# Altium schematic sheet AGCCAL_Top_Level.SchDoc(AGCCAL_Top_Level)
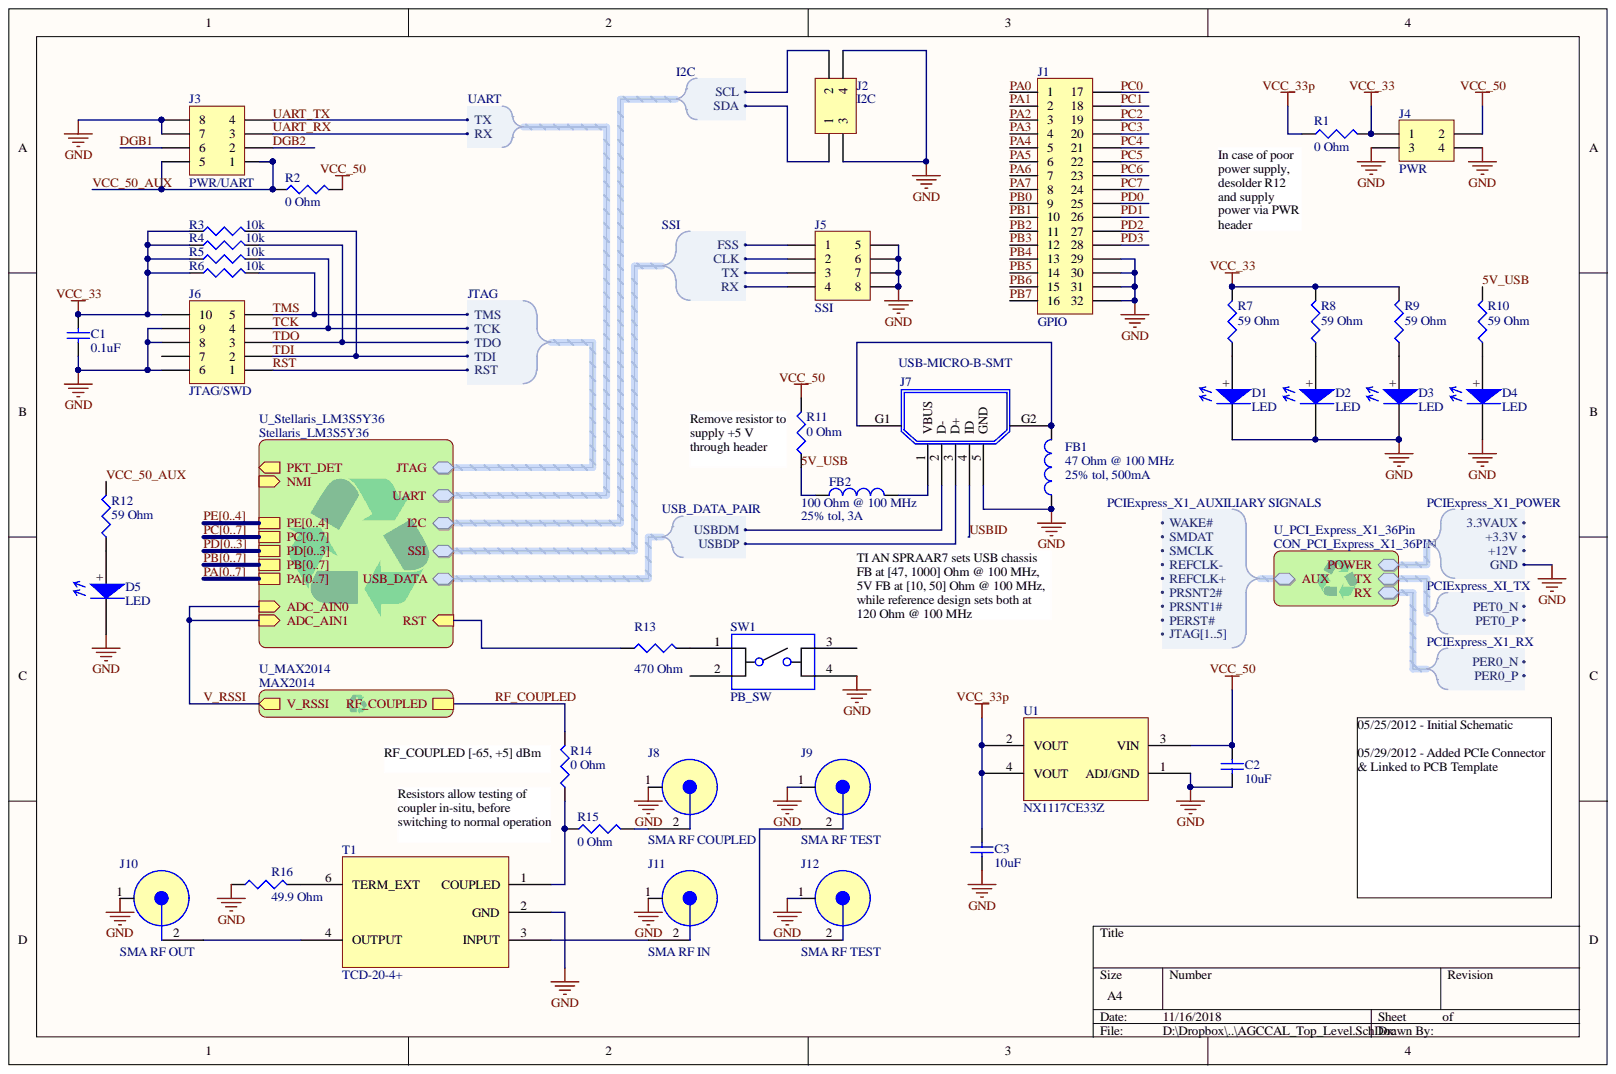
[diagram p0: AGCCAL_Top_Level.SchDoc]

## Parts
C1  value 0.1uF  [1608[0603]]  (hidden comment: Cap Semi)
C2  value 10uF  [C1206]  (hidden comment: Cap Semi)
C3  value 10uF  [C1206]  (hidden comment: Cap Semi)
D1  LED  [0603_SMT_POLAR]
D2  LED  [0603_SMT_POLAR]
D3  LED  [0603_SMT_POLAR]
D4  LED  [0603_SMT_POLAR]
D5  LED  [0603_SMT_POLAR]
FB1  25% tol, 500mA  value 47 Ohm @ 100 MHz  [0603_SMT]
FB2  25% tol, 3A  value 100 Ohm @ 100 MHz  [0603_SMT]
J1  GPIO  [2.54mm_16x2_HDR]
J2  I2C  [2.54mm_2x2_HDR]
J3  PWR/UART  [1.27mm_2x4_SMT_HDR]
J4  PWR  [HDR2X2]
J5  SSI  [2.54mm_4x2_HDR]
J6  JTAG/SWD  [1.27mm_2x5_SMT_HDR]
J7  USB-MICRO-B-SMT  [USB_MICROB_SMT_R2]
J8  SMA RF COUPLED  [SMA-JACK-THROUGH-MOLEX_5]
J9  SMA RF TEST  [SMA-JACK-THROUGH-MOLEX_5]
J10  SMA RF OUT  [SMA-JACK-THROUGH-MOLEX_5]
J11  SMA RF IN  [SMA-JACK-THROUGH-MOLEX_5]
J12  SMA RF TEST  [SMA-JACK-THROUGH-MOLEX_5]
R1  value 0 Ohm  [0805_SMT]
R2  value 0 Ohm  [0805_SMT]  (hidden comment: Res3)
R3  value 10k  [J1-0603]  (hidden comment: Res3)
R4  value 10k  [J1-0603]  (hidden comment: Res3)
R5  value 10k  [J1-0603]  (hidden comment: Res3)
R6  value 10k  [J1-0603]  (hidden comment: Res3)
R7  value 59 Ohm  [J1-0603]  (hidden comment: Res3)
R8  value 59 Ohm  [J1-0603]  (hidden comment: Res3)
R9  value 59 Ohm  [J1-0603]  (hidden comment: Res3)
R10  value 59 Ohm  [J1-0603]  (hidden comment: Res3)
R11  value 0 Ohm  [0805_SMT]
R12  value 59 Ohm  [J1-0603]  (hidden comment: Res3)
R13  value 470 Ohm  [J1-0603]
R14  value 0 Ohm  [0805_SMT]  (hidden comment: Res3)
R15  value 0 Ohm  [0805_SMT]  (hidden comment: Res3)
R16  value 49.9 Ohm  [0805_SMT]  (hidden comment: Res3)
SW1  PB_SW  [PUSHBUTTON_SW2_SMT]
T1  TCD-20-4+  [DB714-MINICIRCUITS]
U1  NX1117CE33Z  [NX1117CE33Z-SOT223]

## Nets
GND: C1.1 C2.1 C3.1 D1.- D2.- D3.- D4.- D5.- FB1.1 J1.29 J1.30 J1.31 J1.32 J2.3 J2.4 J3.7 J3.8 J4.3 J4.4 J5.5 J5.6 J5.7 J5.8 J6.6 J6.8 J6.9 J7.5(GND) J8.1 J9.1 J10.1 J11.1 J12.1 R16.1 SW1.4 T1.2(GND) U1.1(ADJ/GND)
VCC_33: C1.2 J4.1 J6.10 R1.2 R3.1 R4.1 R5.1 R6.1 R7.2 R8.2 R9.2
VCC_33p: C3.2 R1.1 U1.2(VOUT) U1.4(VOUT)
VCC_50: C2.2 J4.2 R2.2 R11.2 U1.3(VIN)
5V_USB_1: FB2.1 R10.2 R11.1  [also labelled 5V_USB]
~{RST_1}: J6.1  [also labelled ~{RST}]
DGB1_1: J3.6  [also labelled DGB1]
DGB2_1: J3.2  [also labelled DGB2]
PA0: J1.1  [also labelled PA]
PA1: J1.2  [also labelled PA]
PA2: J1.3  [also labelled PA]
PA3: J1.4  [also labelled PA]
PA4: J1.5  [also labelled PA]
PA5: J1.6  [also labelled PA]
PA6: J1.7  [also labelled PA]
PA7: J1.8  [also labelled PA]
PB0: J1.9  [also labelled PB]
PB1: J1.10  [also labelled PB]
PB2: J1.11  [also labelled PB]
PB3: J1.12  [also labelled PB]
PB4: J1.13  [also labelled PB]
PB5: J1.14  [also labelled PB]
PB6: J1.15  [also labelled PB]
PB7: J1.16  [also labelled PB]
PC0: J1.17  [also labelled PC]
PC1: J1.18  [also labelled PC]
PC2: J1.19  [also labelled PC]
PC3: J1.20  [also labelled PC]
PC4: J1.21  [also labelled PC]
PC5: J1.22  [also labelled PC]
PC6: J1.23  [also labelled PC]
PC7: J1.24  [also labelled PC]
PD0: J1.25  [also labelled PD]
PD1: J1.26  [also labelled PD]
PD2: J1.27  [also labelled PD]
PD3: J1.28  [also labelled PD]
RF_COUPLED_1: R14.2  [also labelled RF_COUPLED]
TCK_1: J6.4 R5.2  [also labelled TCK]
TDI_1: J6.2 R3.2  [also labelled TDI]
TDO_1: J6.3 R4.2  [also labelled TDO]
TMS_1: J6.5 R6.2  [also labelled TMS]
UART_RX_1: J3.3  [also labelled UART_RX]
UART_TX_1: J3.4  [also labelled UART_TX]
USBID_1: J7.4(ID)  [also labelled USBID]
VCC_50_AUX_1: J3.1 J3.5 R2.1 R12.2  [also labelled VCC_50_AUX]
NetD1_+: D1.+ R7.1
NetD2_+: D2.+ R8.1
NetD3_+: D3.+ R9.1
NetD4_+: D4.+ R10.1
NetD5_+: D5.+ R12.1
NetFB1_2: FB1.2 J7.G1 J7.G2
NetFB2_2: FB2.2 J7.1(VBUS)
NetJ2_1: J2.1
NetJ2_2: J2.2
NetJ5_1: J5.1
NetJ5_2: J5.2
NetJ5_3: J5.3
NetJ5_4: J5.4
NetJ6_7: J6.7
NetJ7_2: J7.2(D-)
NetJ7_3: J7.3(D+)
NetJ8_2: J8.2 R15.2
NetJ9_2: J9.2 J12.2
NetJ10_2: J10.2 T1.4(OUTPUT)
NetJ11_2: J11.2 T1.3(INPUT)
NetR13_1: R13.1
NetR13_2: R13.2 SW1.1
NetR14_1: R14.1 R15.1 T1.1(COUPLED)
NetR16_2: R16.2 T1.6(TERM_EXT)
NetSW1_2: SW1.2
NetSW1_3: SW1.3
N00045:
N00046:
N00047:
N00048:
N00049:
N00050:
N00051:
N00052:
N00053:
N00054:
N00055:
N00056:
N00057:
N00058:
N00059:
N00060:
N00061:
PE0:  [also labelled PE]
PE1:  [also labelled PE]
PE2:  [also labelled PE]
PE3:  [also labelled PE]
PE4:  [also labelled PE]
V_RSSI_1:  [also labelled V_RSSI]

## Library data of the parts
C1-C3 = Cap Semi: Capacitor (Semiconductor SIM Model); Simulation: CAP; Signal Integrity: Cap Semi; Published: 8-Jun-2000; PackageReference: C1206; PackageDescription: Chip Capacitor; Library Name: Miscellaneous Devices.IntLib; LatestRevisionNote: IPC-7351 Footprint Added.; LatestRevisionDate: 29-May-2009; Component Kind: Standard; Publisher: Altium Limited
FB1 = Inductor: Value: 47 Ohm @ 100 MHz; Code_IEC: 1005; Simulation: INDUCTOR; Signal Integrity: Inductor; Published: 8-Jun-2000; PackageReference: 0402-A; PackageDescription: Chip Inductor; Library Name: Miscellaneous Devices.IntLib; LatestRevisionNote: IPC-7351 Footprint Added.; LatestRevisionDate: 29-May-2009; Description: Inductor; Component Kind: Standard; Code_JEITA: 0402; Publisher: Altium Limited
FB2 = Inductor: Code_IEC: 1005; Simulation: INDUCTOR; Signal Integrity: Inductor; Published: 8-Jun-2000; PackageReference: 0402-A; PackageDescription: Chip Inductor; Library Name: Miscellaneous Devices.IntLib; LatestRevisionNote: IPC-7351 Footprint Added.; LatestRevisionDate: 29-May-2009; Description: Inductor; Component Kind: Standard; Code_JEITA: 0402; Publisher: Altium Limited
J1 = Header 16X2A: Header, 16-Pin, Dual row; Signal Integrity: Connector; Library Name: Miscellaneous Connectors.IntLib; LatestRevisionNote: Re-released for DXP Platform.; LatestRevisionDate: 17-Jul-2002; Component Kind: Standard; Publisher: Altium Limited
J2 J4 = Header 2X2: Header, 2-Pin, Dual row; Signal Integrity: Connector; Library Name: Miscellaneous Connectors.IntLib; LatestRevisionNote: Re-released for DXP Platform.; LatestRevisionDate: 17-Jul-2002; Component Kind: Standard; Publisher: Altium Limited
J3 J5 = Header 4X2A: Header, 4-Pin, Dual row; Signal Integrity: Connector; Library Name: Miscellaneous Connectors.IntLib; LatestRevisionNote: Re-released for DXP Platform.; LatestRevisionDate: 17-Jul-2002; Component Kind: Standard; Publisher: Altium Limited
J6 = Header 5X2A: Header, 5-Pin, Dual row; Signal Integrity: Connector; Library Name: Miscellaneous Connectors.IntLib; LatestRevisionNote: Re-released for DXP Platform.; LatestRevisionDate: 17-Jul-2002; Component Kind: Standard; Publisher: Altium Limited
J8 = SMA-THROUGH-MOUNT: RF Coupled; Component Kind: Standard; Library Name: RNG_Parts.SchLib
J9-J12 = SMA-THROUGH-MOUNT: Component Kind: Standard; Library Name: RNG_Parts.SchLib
R1-R12 R14-R16 = Res3: Resistor; Simulation: RESISTOR; Signal Integrity: Res; Published: 8-Jun-2000; PackageReference: J1-0603; PackageDescription: Chip Resistor; Library Name: Miscellaneous Devices.IntLib; LatestRevisionNote: IPC-7351 Footprint Added.; LatestRevisionDate: 29-May-2009; Component Kind: Standard; Publisher: Altium Limited
R13 = Res3: Resistor; Value: 470 Ohm; Simulation: RESISTOR; Signal Integrity: Res; Published: 8-Jun-2000; PackageReference: J1-0603; PackageDescription: Chip Resistor; Library Name: Miscellaneous Devices.IntLib; LatestRevisionNote: IPC-7351 Footprint Added.; LatestRevisionDate: 29-May-2009; Component Kind: Standard; Publisher: Altium Limited
SW1 = SMT-PUSHBUTTON-SW: Pushbutton Switch; Component Kind: Standard; Library Name: RNG_Parts.SchLib
D1-D5 = LED: Component Kind: Standard; Library Name: RNG_Parts.SchLib
J7 = USB-MICRO-B-SMT: Component Kind: Standard; Library Name: RNG_Parts.SchLib
T1 = TCD-20-4+: Component Kind: Standard; Library Name: RNG_Parts.SchLib
U1 = NX1117CE33Z: Component Kind: Standard; Library Name: RNG_Parts.SchLib
